# Altium schematic sheet T100CurrentMonitor.SchDoc
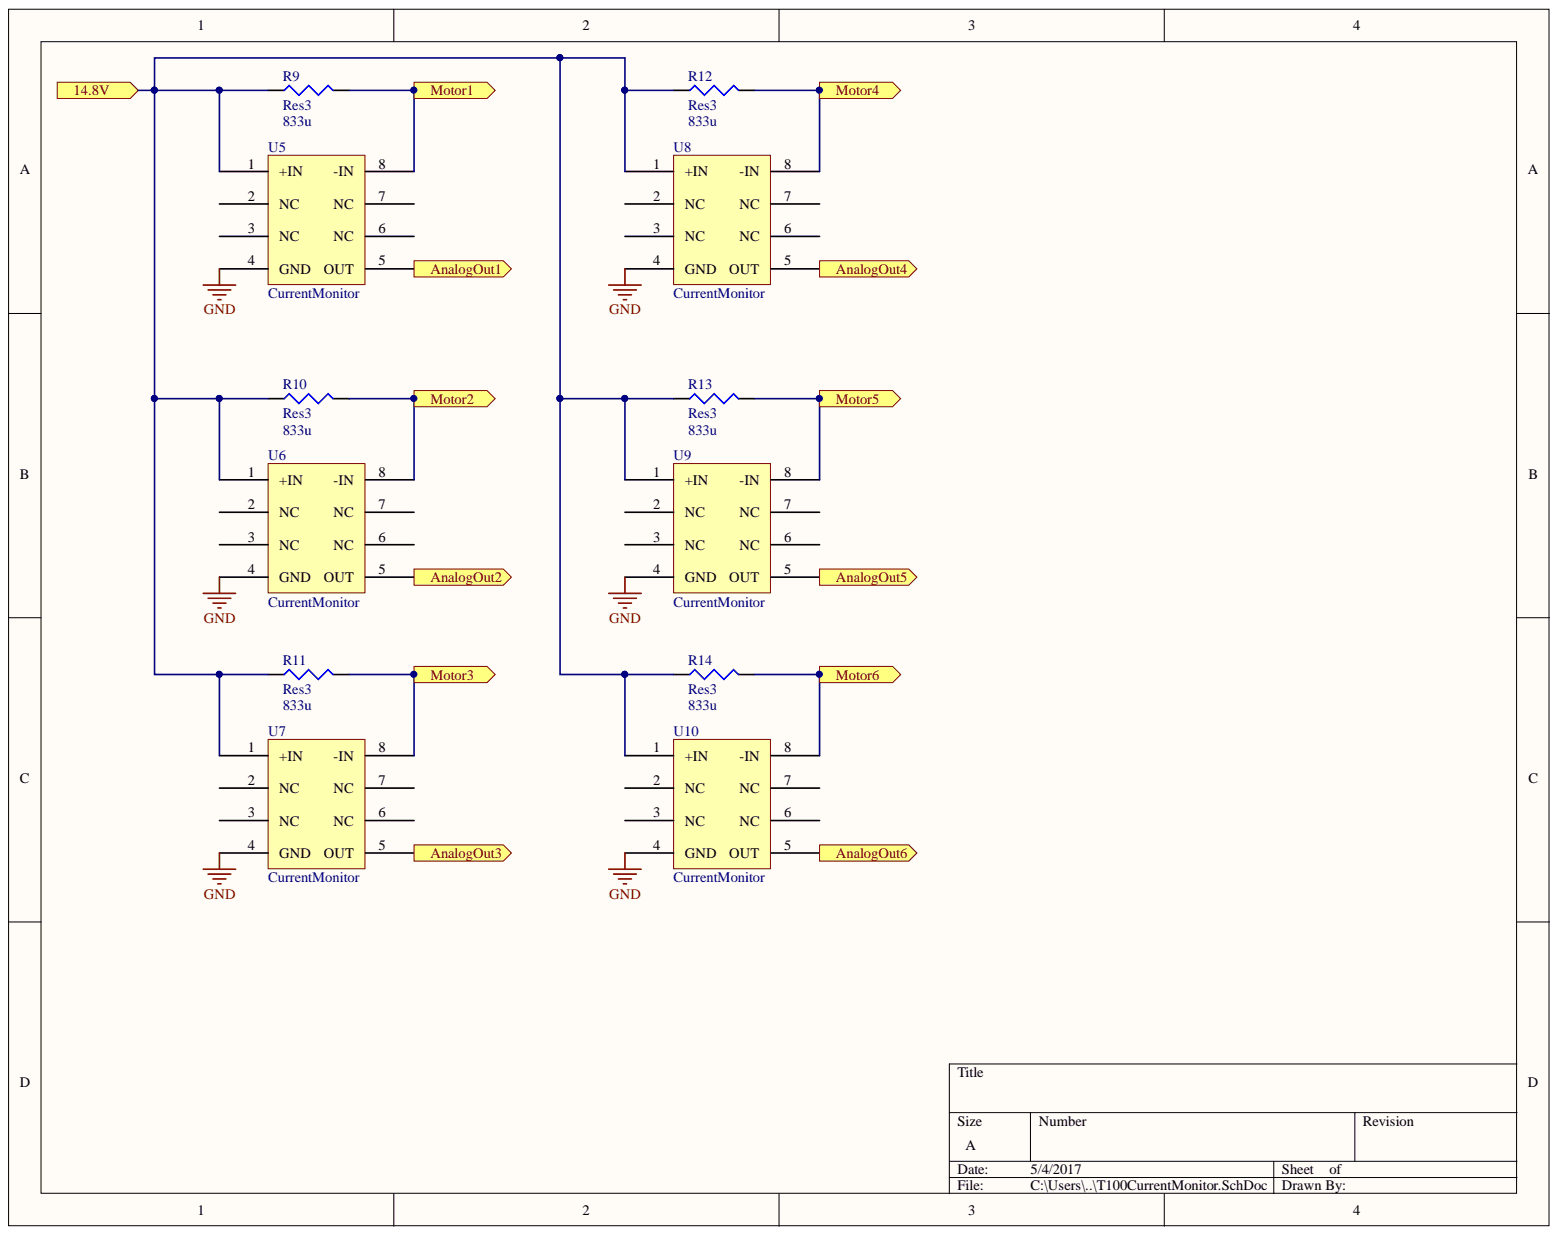
[diagram p0: T100CurrentMonitor.SchDoc]

## Parts
R9  Res3  value 833u  [Resistor075mohms]
R10  Res3  value 833u  [Resistor075mohms]
R11  Res3  value 833u  [Resistor075mohms]
R12  Res3  value 833u  [Resistor075mohms]
R13  Res3  value 833u  [Resistor075mohms]
R14  Res3  value 833u  [Resistor075mohms]
U5  CurrentMonitor  [AD8217]
U6  CurrentMonitor  [AD8217]
U7  CurrentMonitor  [AD8217]
U8  CurrentMonitor  [AD8217]
U9  CurrentMonitor  [AD8217]
U10  CurrentMonitor  [AD8217]

## Ports
14.8V, ANALOGOUT1, ANALOGOUT2, ANALOGOUT3, ANALOGOUT4, ANALOGOUT5, ANALOGOUT6, MOTOR1, MOTOR2, MOTOR3, MOTOR4, MOTOR5, MOTOR6

## Nets
GND: U5.4(GND) U6.4(GND) U7.4(GND) U8.4(GND) U9.4(GND) U10.4(GND)
14.8V: R9.1 R10.1 R11.1 R12.1 R13.1 R14.1 U5.1(+IN) U6.1(+IN) U7.1(+IN) U8.1(+IN) U9.1(+IN) U10.1(+IN) ; port 14.8V
NetR9_2: R9.2 U5.8(-IN) ; port MOTOR1
NetR10_2: R10.2 U6.8(-IN) ; port MOTOR2
NetR11_2: R11.2 U7.8(-IN) ; port MOTOR3
NetR12_2: R12.2 U8.8(-IN) ; port MOTOR4
NetR13_2: R13.2 U9.8(-IN) ; port MOTOR5
NetR14_2: R14.2 U10.8(-IN) ; port MOTOR6
NetU5_5: U5.5(OUT) ; port ANALOGOUT1
NetU6_5: U6.5(OUT) ; port ANALOGOUT2
NetU7_5: U7.5(OUT) ; port ANALOGOUT3
NetU8_5: U8.5(OUT) ; port ANALOGOUT4
NetU9_5: U9.5(OUT) ; port ANALOGOUT5
NetU10_5: U10.5(OUT) ; port ANALOGOUT6

Not connected: U5 2(NC) 3(NC) 6(NC) 7(NC); U6 2(NC) 3(NC) 6(NC) 7(NC); U7 2(NC) 3(NC) 6(NC) 7(NC); U8 2(NC) 3(NC) 6(NC) 7(NC); U9 2(NC) 3(NC) 6(NC) 7(NC); U10 2(NC) 3(NC) 6(NC) 7(NC)

## Library data of the parts
R9-R14 = Res3: Resistor; Value: 833u; Component Kind: Standard; LatestRevisionDate: 29-May-2009; LatestRevisionNote: IPC-7351 Footprint Added.; Library Name: Miscellaneous Devices.IntLib; PackageDescription: Chip Resistor; PackageReference: J1-0603; Published: 8-Jun-2000; Signal Integrity: Res; Simulation: RESISTOR; Publisher: Altium Limited
U5-U10 = AD8217: Component Kind: Standard; Library Name: AD8217.SchLib
